# Altium schematic sheet ST Link.SchDoc
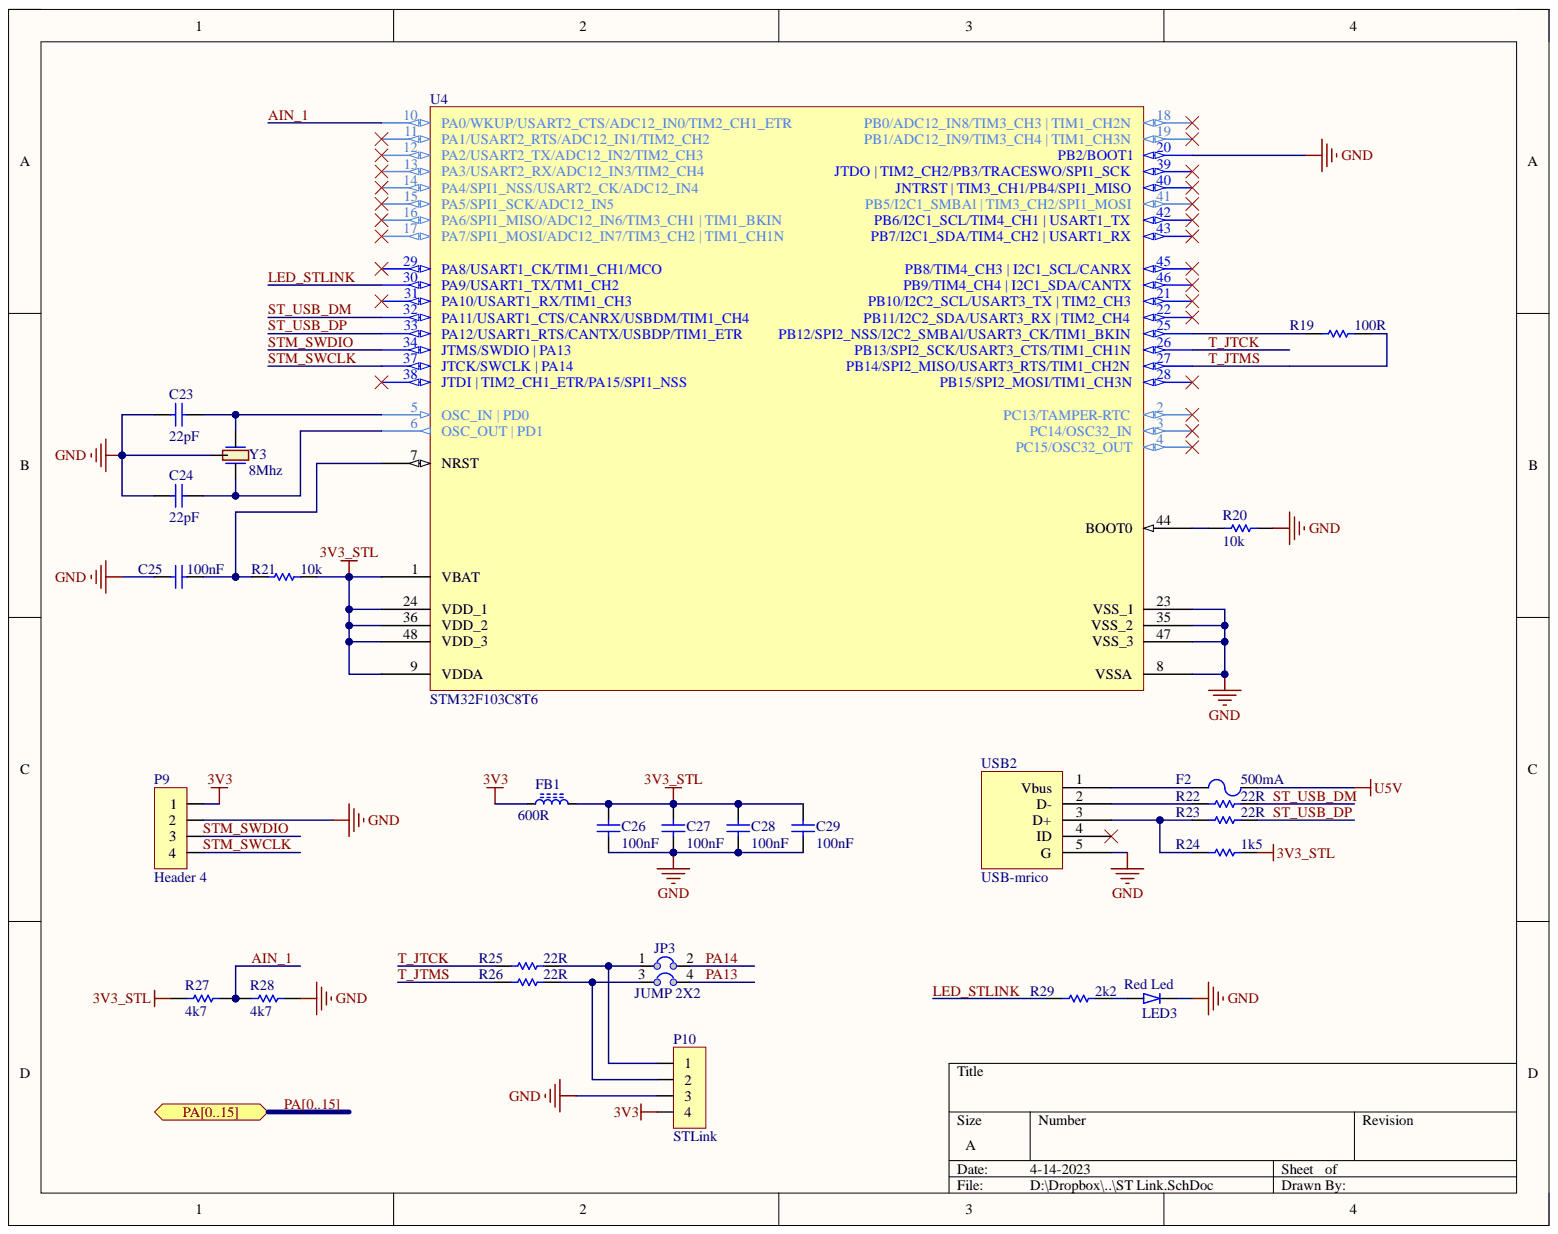
[diagram p0: ST Link.SchDoc]

## Parts
C23  22pF  [C 0603 (1608)]
C24  22pF  [C 0603 (1608)]
C25  100nF  [C 0603 (1608)]
C26  100nF  [C 0603 (1608)]
C27  100nF  [C 0603 (1608)]
C28  100nF  [C 0603 (1608)]
C29  100nF  [C 0603 (1608)]
F2  500mA  [Fuse 0805 SMD]
FB1  600R  [Inductor SMD 0603 (1608)]
JP3  JUMP 2X2  [JUMPER 2x2]
LED3  Red Led  [Led SMD 0603 RED]
P9  Header 4  [Header 4]
P10  STLink  [Header 4]
R19  100R  [R 0603 (1608)]
R20  10k  [R 0603 (1608)]
R21  10k  [R 0603 (1608)]
R22  22R  [R 0603 (1608)]
R23  22R  [R 0603 (1608)]
R24  1k5  [R 0603 (1608)]
R25  22R  [R 0603 (1608)]
R26  22R  [R 0603 (1608)]
R27  4k7  [R 0603 (1608)]
R28  4k7  [R 0603 (1608)]
R29  2k2  [R 0603 (1608)]
U4  STM32F103C8T6  [LQFP48_N]
USB2  USB-mrico  [CONN/USB/MICRO/RA/H]
Y3  8Mhz  [Crystal SMD CSTCE]

## Ports
PA0, PA1, PA2, PA3, PA4, PA5, PA6, PA7, PA8, PA9, PA10, PA11, PA12, PA13, PA14, PA15, PA[0..15]

## Nets
3V3: FB1.1 P9.1 P10.4
3V3_STL: C26.2 C27.2 C28.2 C29.2 FB1.2 R21.2 R24.2 R27.2 U4.1(VBAT) U4.9(VDDA) U4.24(VDD_1) U4.36(VDD_2) U4.48(VDD_3)
GND: C23.2 C24.2 C25.2 C26.1 C27.1 C28.1 C29.1 LED3.2 P9.2 P10.3 R20.2 R28.1 U4.8(VSSA) U4.20(PB2/BOOT1) U4.23(VSS_1) U4.35(VSS_2) U4.47(VSS_3) USB2.5(G) Y3.2
U5V: F2.2
AIN_1: R27.1 R28.2 U4.10(PA0/WKUP/USART2_CTS/ADC12_IN0/TIM2_CH1_ETR)
LED_STLINK: R29.1 U4.30(PA9/USART1_TX/TM1_CH2)
PA13: JP3.4 ; port PA[0..15]  [also labelled PA]
PA14: JP3.2 ; port PA[0..15]  [also labelled PA]
ST_USB_DM: R22.2 U4.32(PA11/USART1_CTS/CANRX/USBDM/TIM1_CH4)
ST_USB_DP: R23.2 U4.33(PA12/USART1_RTS/CANTX/USBDP/TIM1_ETR)
STM_SWCLK: P9.4 U4.37(JTCK/SWCLK_|_PA14)
STM_SWDIO: P9.3 U4.34(JTMS/SWDIO_|_PA13)
T_JTCK: R25.1 U4.26(PB13/SPI2_SCK/USART3_CTS/TIM1_CH1N)
T_JTMS: R19.2 R26.1 U4.27(PB14/SPI2_MISO/USART3_RTS/TIM1_CH2N)
NetC23_1: C23.1 U4.5(OSC_IN_|_PD0) Y3.1
NetC24_1: C24.1 U4.6(OSC_OUT_|_PD1) Y3.3
NetC25_1: C25.1 R21.1 U4.7(NRST)
NetF2_1: F2.1 USB2.1(Vbus)
NetJP3_1: JP3.1 P10.1 R25.2
NetJP3_3: JP3.3 P10.2 R26.2
NetLED3_1: LED3.1 R29.2
NetR19_1: R19.1 U4.25(PB12/SPI2_NSS/I2C2_SMBAl/USART3_CK/TIM1_BKIN)
NetR20_1: R20.1 U4.44(BOOT0)
NetR22_1: R22.1 USB2.2(D-)
NetR23_1: R23.1 R24.1 USB2.3(D+)
PA0: ; port PA[0..15]  [also labelled PA]
PA1: ; port PA[0..15]  [also labelled PA]
PA2: ; port PA[0..15]  [also labelled PA]
PA3: ; port PA[0..15]  [also labelled PA]
PA4: ; port PA[0..15]  [also labelled PA]
PA5: ; port PA[0..15]  [also labelled PA]
PA6: ; port PA[0..15]  [also labelled PA]
PA7: ; port PA[0..15]  [also labelled PA]
PA8: ; port PA[0..15]  [also labelled PA]
PA9: ; port PA[0..15]  [also labelled PA]
PA10: ; port PA[0..15]  [also labelled PA]
PA11: ; port PA[0..15]  [also labelled PA]
PA12: ; port PA[0..15]  [also labelled PA]
PA15: ; port PA[0..15]  [also labelled PA]

Not connected: U4 2(PC13/TAMPER-RTC) 3(PC14/OSC32_IN) 4(PC15/OSC32_OUT) 11(PA1/USART2_RTS/ADC12_IN1/TIM2_CH2) 12(PA2/USART2_TX/ADC12_IN2/TIM2_CH3) 13(PA3/USART2_RX/ADC12_IN3/TIM2_CH4) 14(PA4/SPI1_NSS/USART2_CK/ADC12_IN4) 15(PA5/SPI1_SCK/ADC12_IN5) 16(PA6/SPI1_MISO/ADC12_IN6/TIM3_CH1_|_TIM1_BKIN) 17(PA7/SPI1_MOSI/ADC12_IN7/TIM3_CH2_|_TIM1_CH1N) 18(PB0/ADC12_IN8/TIM3_CH3_|_TIM1_CH2N) 19(PB1/ADC12_IN9/TIM3_CH4_|_TIM1_CH3N) 21(PB10/I2C2_SCL/USART3_TX_|_TIM2_CH3) 22(PB11/I2C2_SDA/USART3_RX_|_TIM2_CH4) 28(PB15/SPI2_MOSI/TIM1_CH3N) 29(PA8/USART1_CK/TIM1_CH1/MCO) 31(PA10/USART1_RX/TIM1_CH3) 38(JTDI_|_TIM2_CH1_ETR/PA15/SPI1_NSS) 39(JTDO_|_TIM2_CH2/PB3/TRACESWO/SPI1_SCK) 40(JNTRST_|_TIM3_CH1/PB4/SPI1_MISO) 41(PB5/I2C1_SMBAl_|_TIM3_CH2/SPI1_MOSI) 42(PB6/I2C1_SCL/TIM4_CH1_|_USART1_TX) 43(PB7/I2C1_SDA/TIM4_CH2_|_USART1_RX) 45(PB8/TIM4_CH3_|_I2C1_SCL/CANRX) 46(PB9/TIM4_CH4_|_I2C1_SDA/CANTX); USB2 4(ID)

## Library data of the parts
C23-C29 = Capacitor NP: Capacitor non-pol; Component Kind: Standard; Library Name: BKIT4U_LIB.SchLib
F2 = Fuse: Component Kind: Standard; Description: Fuse; Library Name: BKIT4U_LIB.SchLib
FB1 = Ferrite Breab: Impedance @ Frequency 600 Ohm @ 100MHz; Current Rating 600 mA; DC Resistance (DCR) 0.3 Ohm Max; Component Kind: Standard; Library Name: BKIT4U_LIB.SchLib
LED3 = Led: Typical LED; Component Kind: Standard; Library Name: BKIT4U_LIB.SchLib
P9 P10 = Header 4: Header, 4-Pin; Component Kind: Standard; Library Name: BKIT4U_LIB.SchLib
R19-R29 = Resistor: Component Kind: Standard; Description: Resistor; LatestRevisionDate: 17-Jul-2002; LatestRevisionNote: Re-released for DXP Platform.; Library Name: BKIT4U_LIB.SchLib; PackageReference: AXIAL-0.3; Published: 8-Jun-2000; Publisher: Altium Limited
U4 = STM32F103C8T6: STM32 ARM-based 32-bit MCU with 64 Kbytes Flash, 48-pin LQFP, Industrial Temperature; Component Kind: Standard; ComponentLink1Description: Manufacturer Link; ComponentLink1URL: www.st.com/stm32; ComponentLink2Description: Datasheet; ComponentLink2URL: http://www.st.com/stonline/products/literature/ds/13587.pdf; DatasheetVersion: rev6, Mar-2008; Library Name: BKIT4U_LIB.SchLib; PackageDescription: 48-Pin Low-Profile Quad Flat Pack, 7 x 7 mm Body, 0.5 mm Pitch (LQFP48); PackageReference: LQFP48; PackageVersion: Mar-2008; Published: 30-May-2008; Publisher: Altium Limited
USB2 = usb-STM: Component Kind: Standard; Library Name: STM32F103C8T6 Bluepill.SchLib
Y3 = Crystal CSTCE: Component Kind: Standard; Library Name: BKIT4U_LIB.SchLib
JP3 = JUMP 2X2: Component Kind: Standard; LatestRevisionDate: 17-Jul-2002; LatestRevisionNote: Re-released for DXP Platform.; Library Name: BKIT4U_LIB.SchLib; Publisher: Altium Limited
